# Altium schematic sheet SD_Card.SchDoc
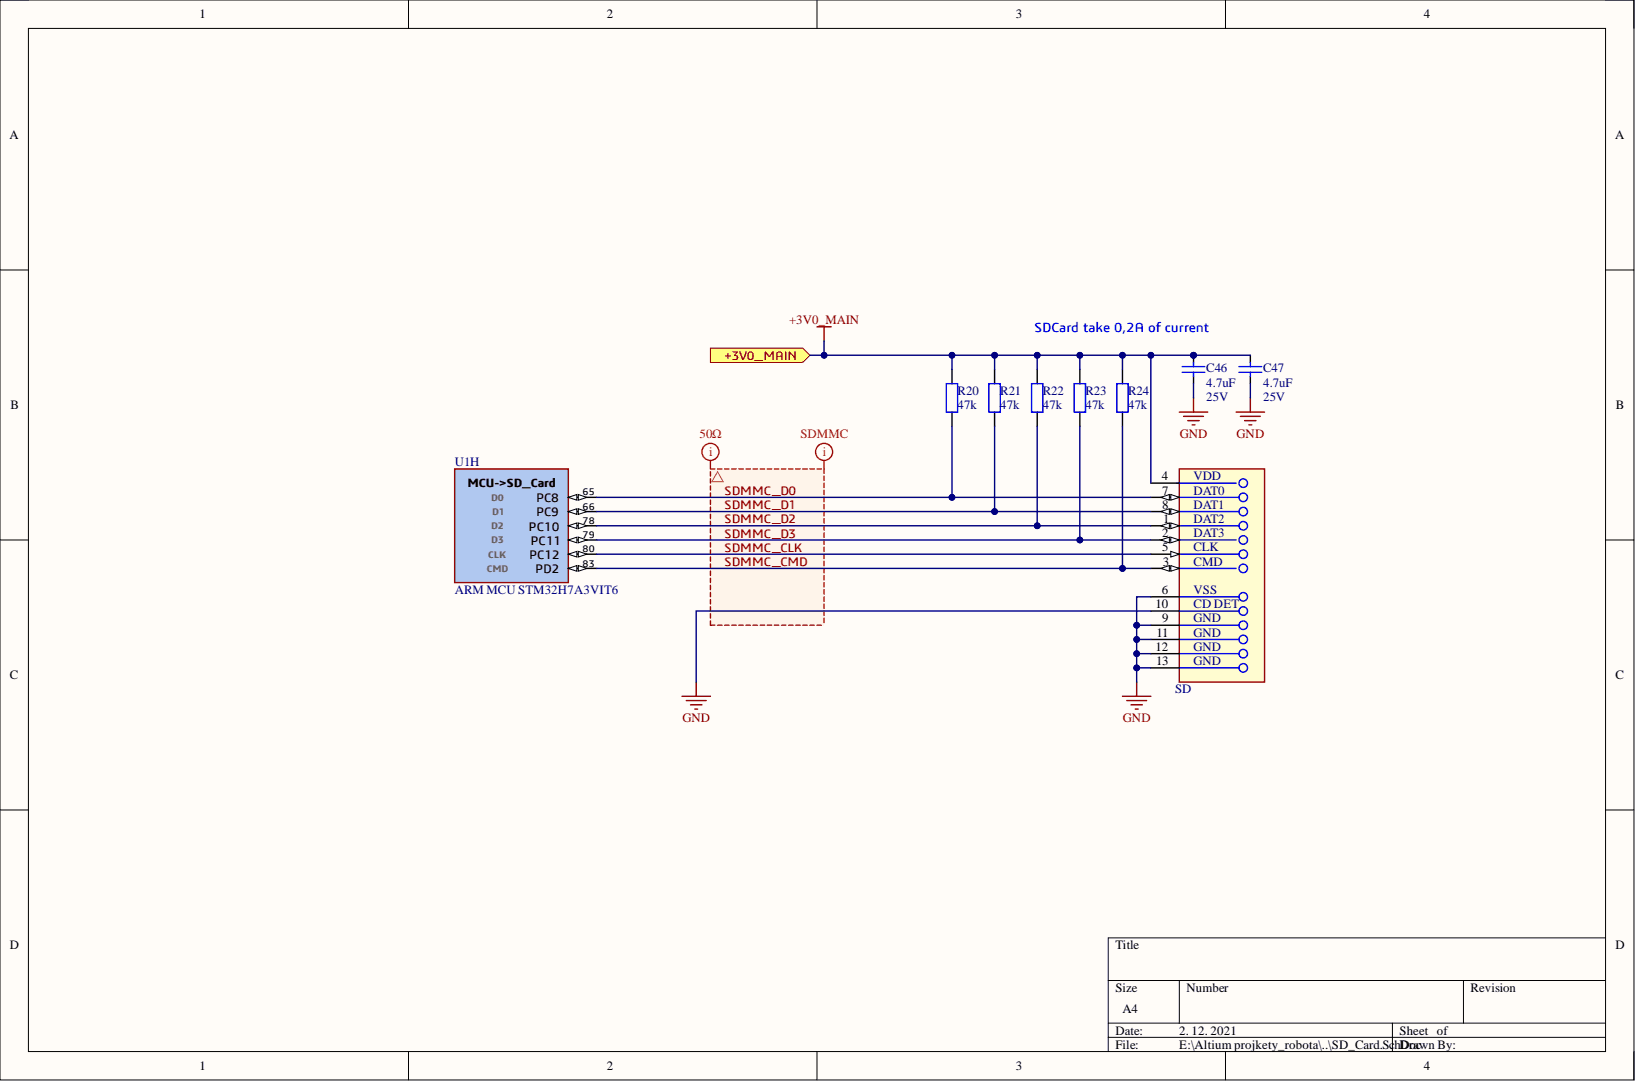
[diagram p0: SD_Card.SchDoc]

## Parts
C46  4.7uF  Voltage: 25V  [CAPACITOR_0603_(1608)]
C47  4.7uF  Voltage: 25V  [CAPACITOR_0603_(1608)]
R20  47k  [RESISTOR_0603_(1608)]
R21  47k  [RESISTOR_0603_(1608)]
R22  47k  [RESISTOR_0603_(1608)]
R23  47k  [RESISTOR_0603_(1608)]
R24  47k  [RESISTOR_0603_(1608)]
SD  DM3CS-SF  [DM3CS-SF]  (value not drawn)
U1  ARM MCU STM32H7A3VIT6  Manufacturer Part Number: STM32H7A3VIT6  [STM-LQFP100_N - Edited]  (part H)

## Ports
+3V0_MAIN

## Nets
GND: C46.2 C47.2 SD.6(VSS) SD.9 SD.10 SD.11 SD.12 SD.13(GND)
+3V0_MAIN: C46.1 C47.1 R20.2 R21.2 R22.2 R23.2 R24.2 SD.4 ; port +3V0_MAIN
SDMMC_CLK: SD.5 U1.80(PC12)
SDMMC_CMD: R24.1 SD.3 U1.83(PD2)
SDMMC_D0: R20.1 SD.7 U1.65(PC8)
SDMMC_D1: R21.1 SD.8(DAT1) U1.66(PC9)
SDMMC_D2: R22.1 SD.1(DAT2) U1.78(PC10)
SDMMC_D3: R23.1 SD.2 U1.79(PC11)

## Library data of the parts
C46 C47 = CAPACITOR: Manufacturer: Murata; Manufacturer Part Number 1: GRM188R61E475KE11D; Dielectric: X5R; ESR: 0.28R; Material: Ceramic; Tolerance: 10%; Capacitance: 4.7uF; Case Code (Imperial): 0603; Case Code (Metric): 1608; Case/Package: 0603; Component Kind: Standard; ComponentLink1Description: https://datasheet.ciiva.com/46444/1769893-46444982.pdf?src-supplier=Element14; ComponentLink1URL: https://datasheet.ciiva.com/46444/1769893-46444982.pdf?src-supplier=Element14; ComponentLink2Description: https://datasheet.ciiva.com/46444/1769893-46444983.pdf?src-supplier=Element14; ComponentLink2URL: https://datasheet.ciiva.com/46444/1769893-46444983.pdf?src-supplier=Element14; ComponentLink3Description: https://datasheet.ciiva.com/14891/partnumbering-e-01-14891102.pdf?src-supplier=Digi-Key; ComponentLink3URL: https://datasheet.ciiva.com/14891/partnumbering-e-01-14891102.pdf?src-supplier=Digi-Key; ComponentLink4Description: https://datasheet.ciiva.com/14891/partnumbering-e-01-14891103.pdf?src-supplier=Digi-Key; ComponentLink4URL: https://datasheet.ciiva.com/14891/partnumbering-e-01-14891103.pdf?src-supplier=Digi-Key; Depth: 0.8mm; Description: CAPACITOR; Height: 0.8mm; Lead Free: Lead Free; Length: 1.6mm; Max Operating Temperature: 85°C; Min Operating Temperature: -55°C; Mount: Surface Mount; Package Quantity: 4000; Packaging: Cut Tape; Pricing 1: 10=0,35700, 100=100,00000, 500=0,15900, 1000=0,12800, 2000=0,10200 (CHF); REACH SVHC: No SVHC; RoHS: Yes; Stock 1: 95119; Supplier 1: Farnell; Supplier Part Number 1: 2426959; Thickness: 0.031inch; Voltage Rating: 25V; Voltage Rating (DC): 25V; Width: 0.031inch; Library Name: RLCFB.SchLib
R20-R24 = RESISTOR: Power Rating: 500mW; Component Kind: Standard; Description: RESISTOR; Resistance: 47k; Library Name: RLCFB.SchLib
SD = MICRO SD HINGE Card Connector: CONN MICRO SD CARD HINGED TYPE; Manufacturer: Hirose; Connector Type: Connector,Socket; Contact Resistance: 100mR; Current Rating: 500mA; Gender: Female; Insulation Resistance: 1GR; Number of Contacts: 8; Pitch: 1.1mm; Voltage Rating (AC): 125V; Category: Memory Connectors; Component Kind: Standard; ComponentLink1Description: https://datasheet.ciiva.com/683/e60900232-683360.pdf?src-supplier=Digi-Key; ComponentLink1URL: https://datasheet.ciiva.com/683/e60900232-683360.pdf?src-supplier=Digi-Key; ComponentLink2Description: https://datasheet.ciiva.com/3122/e60900232-3122536.pdf?src-supplier=Avnet; ComponentLink2URL: https://datasheet.ciiva.com/3122/e60900232-3122536.pdf?src-supplier=Avnet; ComponentLink3Description: https://datasheet.ciiva.com/4581/e60900232-38395-4581034.pdf?src-supplier=Mouser; ComponentLink3URL: https://datasheet.ciiva.com/4581/e60900232-38395-4581034.pdf?src-supplier=Mouser; ComponentLink4Description: https://datasheet.ciiva.com/16434/e60900232-16434058.pdf?src-supplier=Future+Electronics; ComponentLink4URL: https://datasheet.ciiva.com/16434/e60900232-16434058.pdf?src-supplier=Future+Electronics; ComponentLink5Description: https://datasheet.ciiva.com/683/e60900232-683361.pdf?src-supplier=Digi-Key; ComponentLink5URL: https://datasheet.ciiva.com/683/e60900232-683361.pdf?src-supplier=Digi-Key; ComponentLink6Description: https://datasheet.ciiva.com/7651/1465328-7651895.pdf?src-supplier=Element14; ComponentLink6URL: https://datasheet.ciiva.com/7651/1465328-7651895.pdf?src-supplier=Element14; ComponentLink7Description: https://datasheet.ciiva.com/683/e60900232-683359.pdf?src-supplier=Digi-Key; ComponentLink7URL: https://datasheet.ciiva.com/683/e60900232-683359.pdf?src-supplier=Digi-Key; Contact Material: Gold,Copper Alloy,Copper; Contact Plating: Gold; Depth: 1.83mm; Flammability Rating: UL94 V-0; Housing Material: Polymer; Lead Free: Lead Free; Length: 14.1mm; Library Name: BB1_SCH_Library.SchLib; Manufacturer Part Number: DM3CS-SF; Max Current Rating: 500mA; Max Operating Temperature: 85°C; Min Operating Temperature: -25°C; Mount: Surface Mount; Number of Positions: 8; Number of Rows: 1; Orientation: Right Angle; Package Quantity: 1; Packaging: Tape and Reel; Pricing 1: 1=1,10000, 32=1,05000, 97=0,90600, 260=0,83400, 650=0,59400 (GBP); Radiation Hardening: No; REACH SVHC: Unknown; RoHS: Yes; Stock 1: 8009; Supplier 1: Farnell; Supplier 2: Mouser; Supplier Part Number 1: 1764376; Supplier Part Number 2: 798-DM3CSSF; Termination: Solder; Width: 13.8mm
U1 = STM32H7A3VIT6_LQFP100: STM32H7A3VIT6 -  32-bit Arm® Cortex®-M7 280 MHz MCUs, up to 2-Mbyte Flash memory, 1.4Mbyte RAM, 46 com. and analog interfaces; Manufacturer: STMicroelectronics; Category: Microcontrollers; Component Kind: Standard; ComponentLink1Description: Datasheet; ComponentLink1URL: https://www.st.com/resource/en/datasheet/stm32h7a3vi.pdf; Library Name: BB1_SCH_Library.SchLib; RoHS: No; Supplier 1: Mouser; Supplier Part Number 1: 511-STM32H7A3VIT6
